# Altium schematic sheet FPGA_PWR_MISC.SchDoc(FPGA_PWR_MISC)
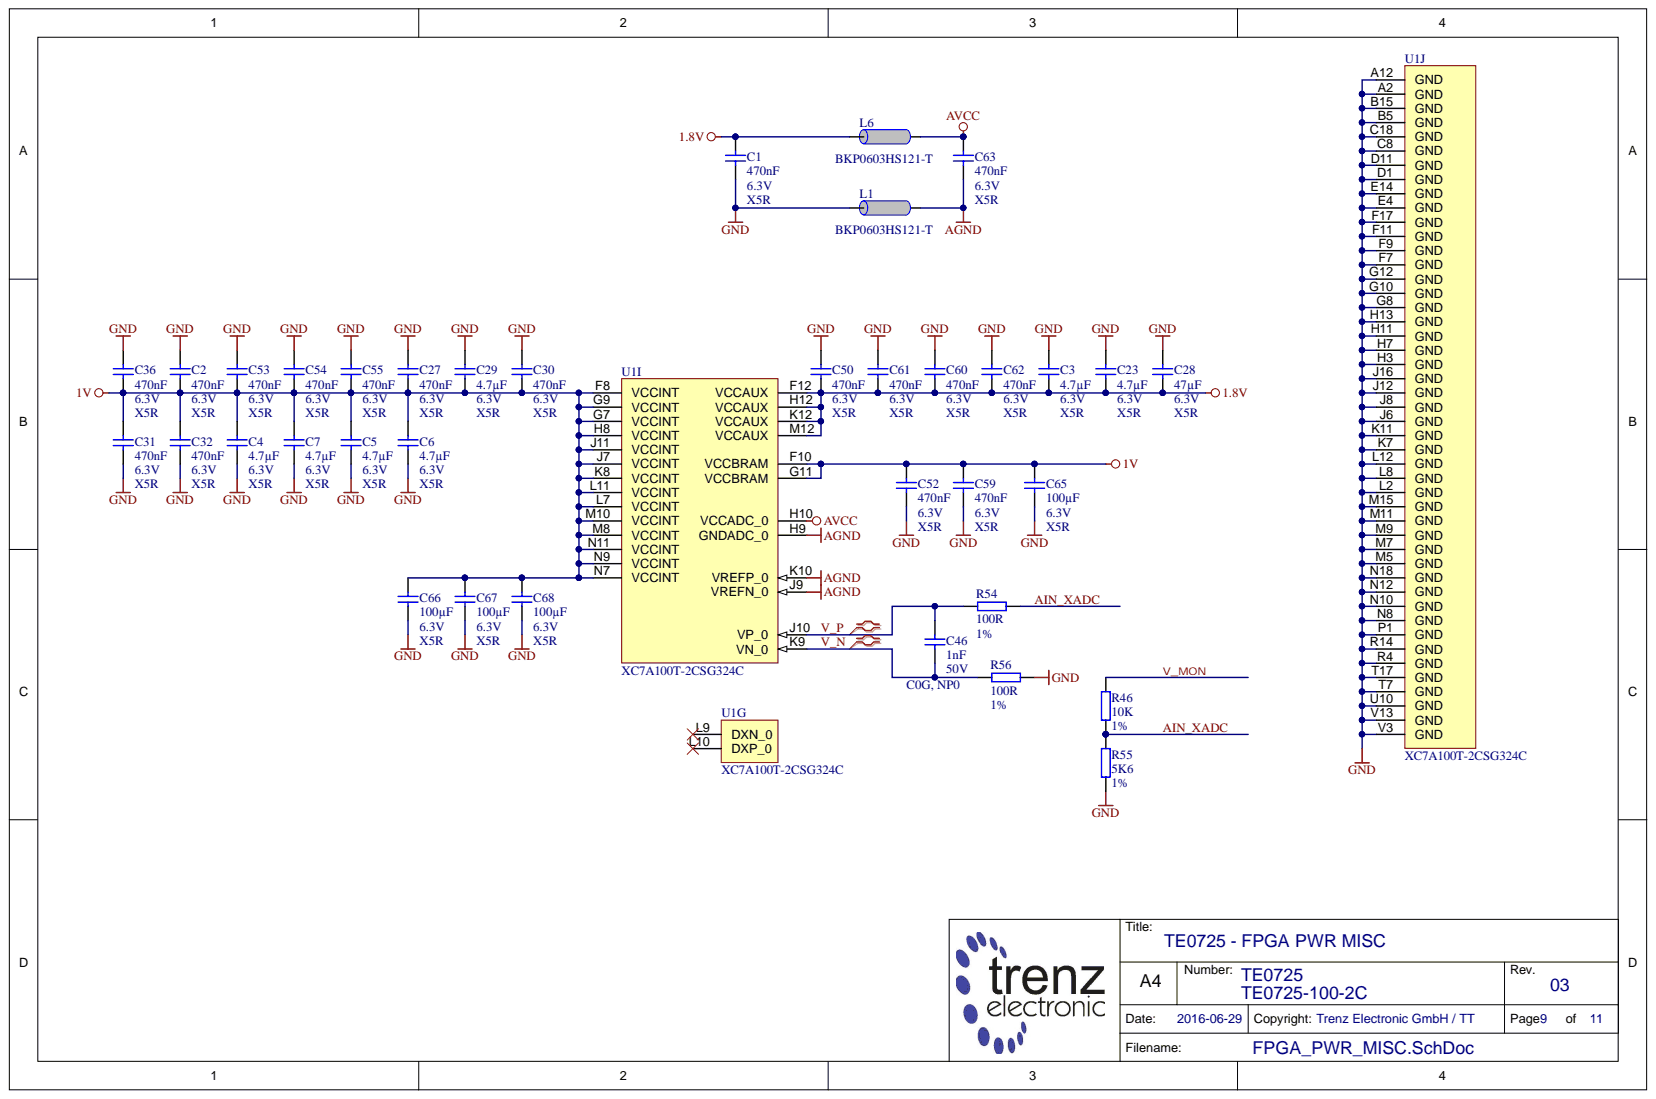
[diagram p0: FPGA_PWR_MISC.SchDoc]

## Parts
C1  470nF  Technology: X5R  Voltage: 6.3V  [CAPC0603X33N]
C2  470nF  Voltage: 6.3V  [CAPC0603X33N]
C3  4.7µF  Technology: X5R  Voltage: 6.3V  [CAPC1005X60N]
C4  4.7µF  Technology: X5R  Voltage: 6.3V  [CAPC1005X60N]
C5  4.7µF  Technology: X5R  Voltage: 6.3V  [CAPC1005X60N]
C6  4.7µF  Technology: X5R  Voltage: 6.3V  [CAPC1005X60N]
C7  4.7µF  Technology: X5R  Voltage: 6.3V  [CAPC1005X60N]
C23  4.7µF  Technology: X5R  Voltage: 6.3V  [CAPC1005X60N]
C27  470nF  Voltage: 6.3V  [CAPC0603X33N]
C28  47µF  Technology: X5R  Voltage: 6.3V  [CAPC2012X135N]
C29  4.7µF  Technology: X5R  Voltage: 6.3V  [CAPC1005X60N]
C30  470nF  Technology: X5R  Voltage: 6.3V  [CAPC0603X33N]
C31  470nF  Technology: X5R  Voltage: 6.3V  [CAPC0603X33N]
C32  470nF  Technology: X5R  Voltage: 6.3V  [CAPC0603X33N]
C36  470nF  Voltage: 6.3V  [CAPC0603X33N]
C46  1nF  Technology: C0G, NP0  Voltage: 50V  [CAPC1005X55N]
C50  470nF  Technology: X5R  Voltage: 6.3V  [CAPC0603X33N]
C52  470nF  Technology: X5R  Voltage: 6.3V  [CAPC0603X33N]
C53  470nF  Voltage: 6.3V  [CAPC0603X33N]
C54  470nF  Voltage: 6.3V  [CAPC0603X33N]
C55  470nF  Voltage: 6.3V  [CAPC0603X33N]
C59  470nF  Technology: X5R  Voltage: 6.3V  [CAPC0603X33N]
C60  470nF  Technology: X5R  Voltage: 6.3V  [CAPC0603X33N]
C61  470nF  Technology: X5R  Voltage: 6.3V  [CAPC0603X33N]
C62  470nF  Technology: X5R  Voltage: 6.3V  [CAPC0603X33N]
C63  470nF  Technology: X5R  Voltage: 6.3V  [CAPC0603X33N]
C65  100µF  Technology: X5R  Voltage: 6.3V  [CAPC3216X180N]
C66  100µF  Technology: X5R  Voltage: 6.3V  [CAPC3216X180N]
C67  100µF  Technology: X5R  Voltage: 6.3V  [CAPC3216X180N]
C68  100µF  Technology: X5R  Voltage: 6.3V  [CAPC3216X180N]
L1  BKP0603HS121-T  [INDC0603X33N]
L6  BKP0603HS121-T  [INDC0603X33N]
R46  10K  Tolerance: 1%  [RESC1005X40N]
R54  100R  [RESC1005X40N]
R55  5K6  Tolerance: 1%  [RESC1005X40N]
R56  100R  Tolerance: 1%  [RESC1005X40N]
U1  XC7A100T-2CSG324C  [BGA324C80P18X18_1500X1500X150N]  (parts G,I,J)
U1  XC7A35T-2CSG324C  [BGA324C80P18X18_1500X1500X150N]  (value not drawn)  (part G)
U1  XC7A35T-2CSG324C  [BGA324C80P18X18_1500X1500X150N]  (value not drawn)  (part I)
U1  XC7A35T-2CSG324C  [BGA324C80P18X18_1500X1500X150N]  (value not drawn)  (part J)
U1  XC7A15T-1CSG324C  [BGA324C80P18X18_1500X1500X150N]  (value not drawn)  (part G)
U1  XC7A15T-1CSG324C  [BGA324C80P18X18_1500X1500X150N]  (value not drawn)  (part I)
U1  XC7A15T-1CSG324C  [BGA324C80P18X18_1500X1500X150N]  (value not drawn)  (part J)

## Nets
1.8V: C1.1 C3.2 C23.1 C28.1 C50.2 C60.1 C61.1 C62.1 L6.2 U1.F12 U1.H12 U1.K12 U1.M12
1V: C2.2 C4.1 C5.2 C6.2 C7.1 C27.2 C29.1 C30.1 C31.2 C32.2 C36.2 C52.1 C53.2 C54.2 C55.2 C59.1 C65.1 C66.1 C67.1 C68.1 U1.F8 U1.F10 U1.G7 U1.G9 U1.G11 U1.H8 U1.J7 U1.J11 U1.K8 U1.L7 U1.L11 U1.M8 U1.M10 U1.N7 U1.N9 U1.N11
AGND: C63.2 L1.1 U1.H9 U1.J9 U1.K10
AVCC: C63.1 L6.1 U1.H10
GND: C1.2 C2.1 C3.1 C4.2 C5.1 C6.1 C7.2 C23.2 C27.1 C28.2 C29.2 C30.2 C31.1 C32.1 C36.1 C50.1 C52.2 C53.1 C54.1 C55.1 C59.2 C60.2 C61.2 C62.2 C65.2 C66.2 C67.2 C68.2 L1.2 R55.2 R56.2 U1.A2 U1.A12 U1.B5 U1.B15 U1.C8 U1.C18 U1.D1 U1.D11 U1.E4 U1.E14 U1.F7 U1.F9 U1.F11 U1.F17 U1.G8 U1.G10 U1.G12 U1.H3 U1.H7 U1.H11 U1.H13 U1.J6 U1.J8 U1.J12 U1.J16 U1.K7 U1.K11 U1.L2 U1.L8 U1.L12 U1.M5 U1.M7 U1.M9 U1.M11 U1.M15 U1.N8 U1.N10 U1.N12 U1.N18 U1.P1 U1.R4 U1.R14 U1.T7 U1.T17 U1.U10 U1.V3 U1.V13
AIN_XADC: R46.2 R54.2 R55.1
V_MON: R46.1
V_N: C46.2 R56.1 U1.K9
V_P: C46.1 R54.1 U1.J10

Not connected: U1 L9 L10

## Library data of the parts
C1 C2 C27 C30-C32 C36 C50 C52-C55 C59-C63 = 24921: KON 470nF X5R 6.3V SMD 20%; Database Table Name: C_0603_0201; Mixed: SMT; Preferred: Yes; Value: 470n; AN: 24921; Case: 0603 (0201); Component Kind: Standard; Library Name: TE.DbLib; Tolerance: 20%
  C2 C27 C36 C53-C55: Technology: X5R
C3-C7 C23 C29 = 24719: KON 4.7µF 6.3V X5R SMD 20%; Database Table Name: C_1005_0402; Mixed: SMT; Preferred: Yes; Value: 4.7u; AN: 24719; Case: 1005 (0402); Component Kind: Standard; Library Name: TE.DbLib; Tolerance: 20%
C28 = 24718: KON 47µF 6,3V  X5R SMD 20%; Database Table Name: C_2012_0805; Mixed: SMT; Preferred: Yes; Value: 47u; AN: 24718; Case: 2012 (0805); Component Kind: Standard; Library Name: TE.DbLib; Tolerance: 20%
C46 = 23752: KON 1nF NP0 SMD 5%; Database Table Name: C_1005_0402; Mixed: SMT; Value: 1n; AN: 23752; Case: 1005 (0402); Component Kind: Standard; Library Name: TE.DbLib; Tolerance: 5%
C65-C68 = 23116: KON 100µF 6,3V X5R SMD 20%; Database Table Name: C_3216_1206; Mixed: SMT; Preferred: Yes; Value: 100u; AN: 23116; Case: 3216 (1206); Component Kind: Standard; Library Name: TE.DbLib; Tolerance: 20%
L1 L6 = 25393: Ferritdurchführung SMD 0201; Database Table Name: L_0603_0201; Mixed: SMT; Preferred: Yes; Tolerance: 25%; AN: 25393; Case: 0603 (0201); Component Kind: Standard; H-ART: BKP0603HS121-T; Library Name: TE.DbLib; Trenz-Stock: Yes; HelpURL: B:\AD\adlib\datasheet\MULTILAYER CHIP BEAD INDUCTORS BK
R46 = 22750: WID SMD 10K 1% 0,063W; Database Table Name: R_1005_0402; Mixed: SMT; Preferred: Yes; AN: 22750; Case: 1005 (0402); Component Kind: Standard; Library Name: TE.DbLib; Technology: TK100; Value: 10K
R54 R56 = 22507: WID SMD 100R 1% 0,063W; Database Table Name: R_1005_0402; Mixed: SMT; Preferred: Yes; Value: 100; AN: 22507; Case: 1005 (0402); Component Kind: Standard; Library Name: TE.DbLib; Technology: TK100
  R54: Tolerance: 1%
R55 = 22760: WID SMD 5K6 1% 0,063W; Database Table Name: R_1005_0402; Mixed: SMT; Value: 5.6K; AN: 22760; Case: 1005 (0402); Component Kind: Standard; Library Name: TE.DbLib; Technology: TK100
U1 = 24908: Database Table Name: PROG_LOGIC; Mixed: SMT; Trenz-Stock: Yes; AN: 24908; Case: CSG324; Component Kind: Standard; Description: XC7A100T-2CSG324C; H-ART: XC7A100T-2CSG324C; Library Name: TE.DbLib; HelpURL: B:\AD\adlib\datasheet\XC7
U1 U1 U1 = 25960: Database Table Name: PROG_LOGIC; Mixed: SMT; Trenz-Stock: Yes; AN: 25960; Case: CSG324; Component Kind: Standard; Description: XC7A35T-2CSG324C; H-ART: XC7A35T-2CSG324C; Library Name: TE.DbLib; HelpURL: B:\AD\adlib\datasheet\XC7
U1 U1 U1 = 26309: Database Table Name: PROG_LOGIC; Mixed: SMT; AN: 26309; Case: CSG324; Component Kind: Standard; Description: XC7A15T-1CSG324C; H-ART: XC7A15T-1CSG324C; Library Name: TE.DbLib; HelpURL: B:\AD\adlib\datasheet\XC7
